# Altium schematic sheet SCH40.001R5.SchDoc
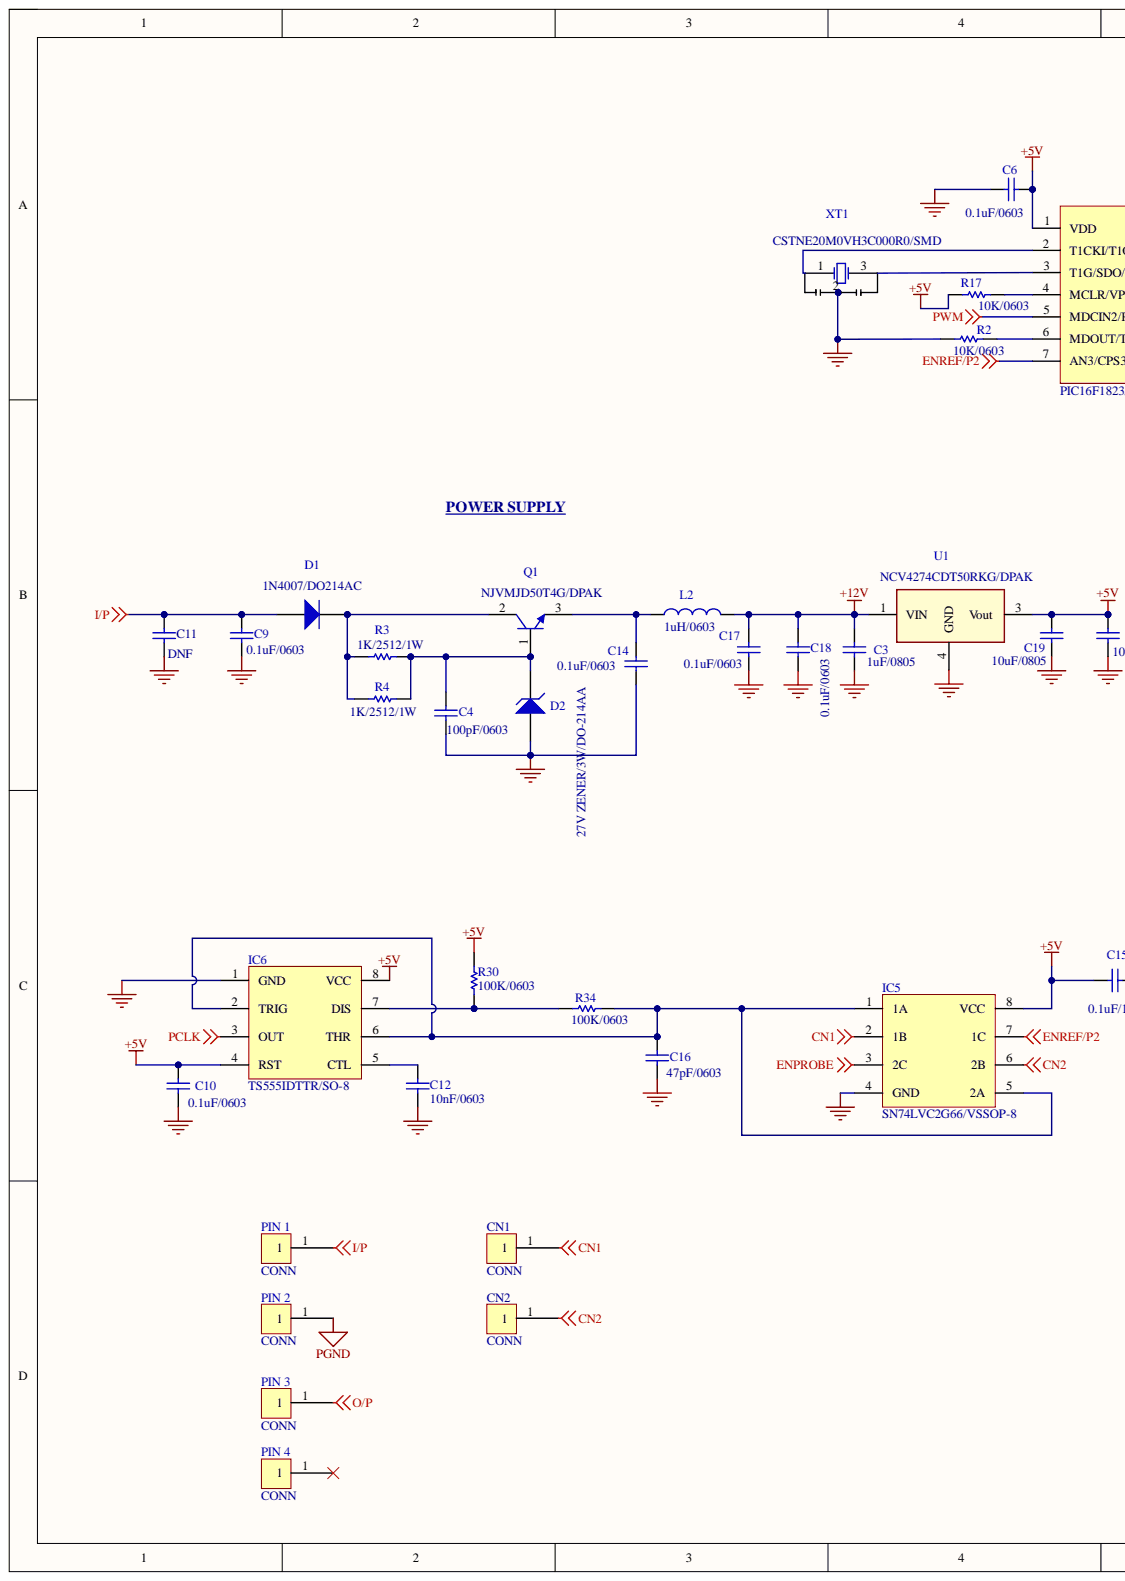
[diagram p0: SCH40.001R5.SchDoc, left]
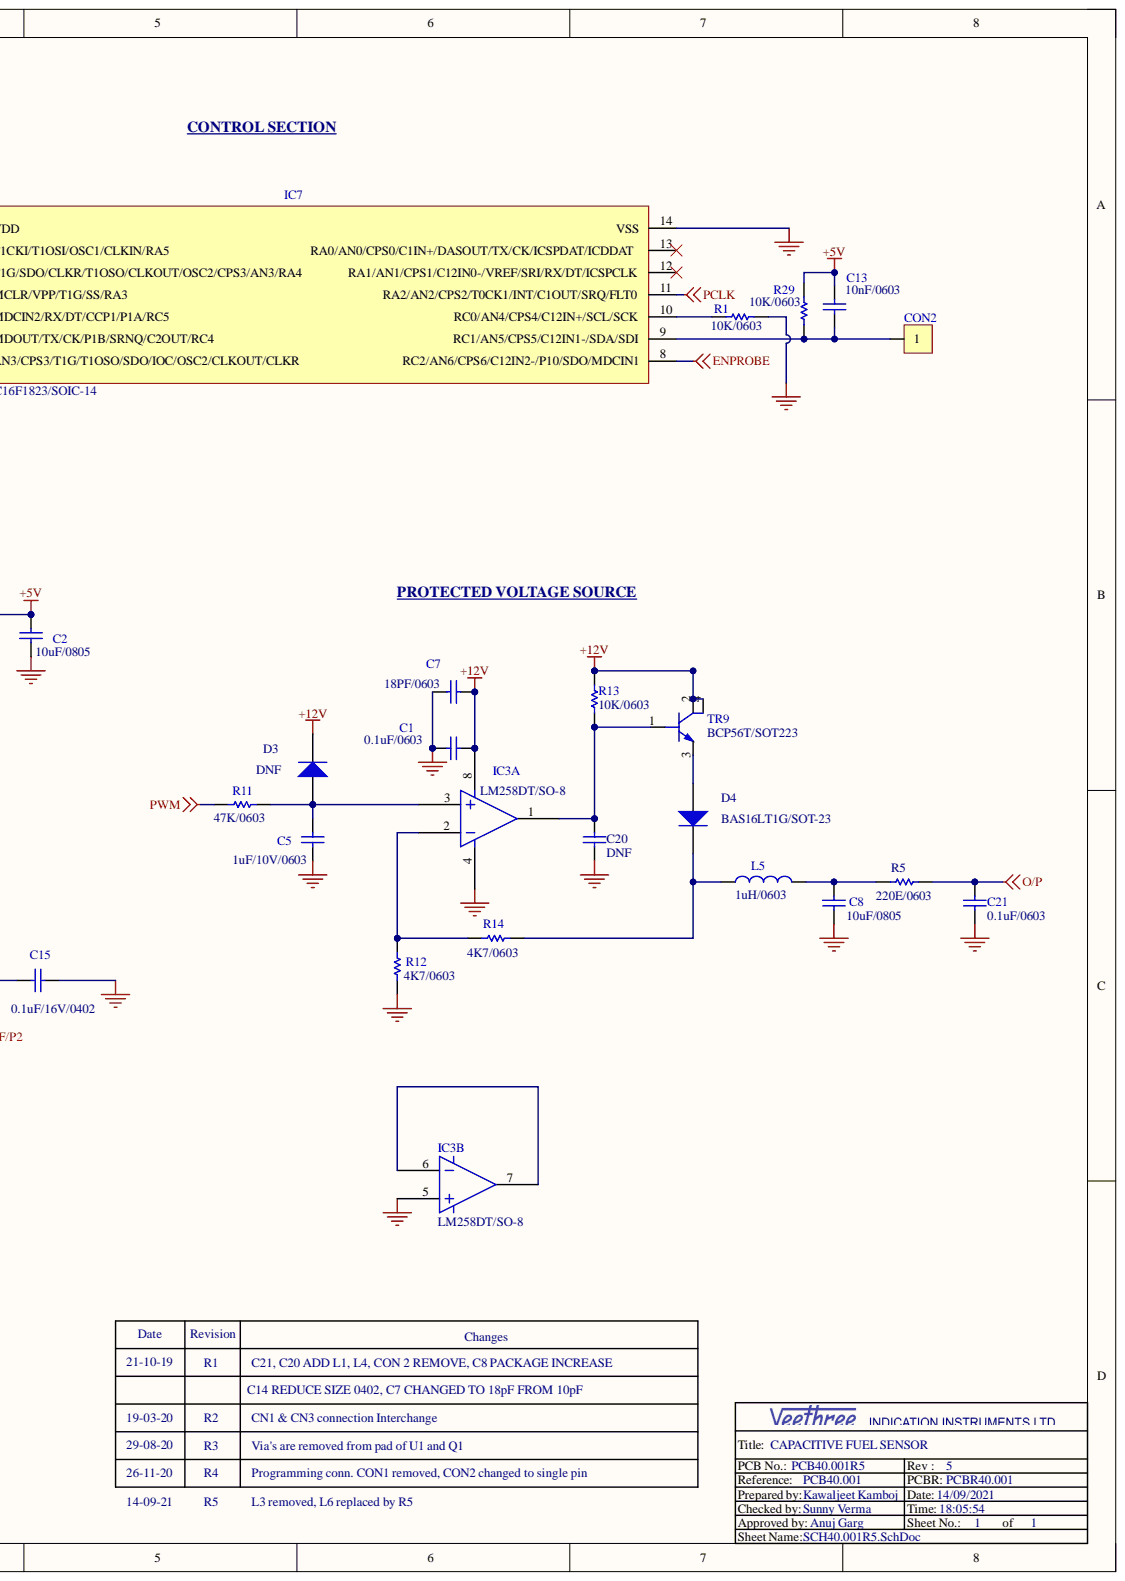
[diagram p1: SCH40.001R5.SchDoc, right]

## Parts
1  (no value)
C1  0.1uF/0603  [0603]
C2  10uF/0805  [0805]
C3  1uF/0805  [0805]
C4  100pF/0603  [0603]
C5  1uF/10V/0603  [0603]
C6  0.1uF/0603  [0603]
C7  18PF/0603  [0603]
C8  10uF/0805  [0805]
C9  0.1uF/0603  [0603]
C10  0.1uF/0603  [0603]
C11  DNF  [0603]
C12  10nF/0603  [0603]
C13  10nF/0603  [0603]
C14  0.1uF/0603  [0603]
C15  0.1uF/16V/0402  [0402]
C16  47pF/0603  [0603]
C17  0.1uF/0603  [0603]
C18  0.1uF/0603  [0603]
C19  10uF/0805  [0805]
C20  DNF  [0603]
C21  0.1uF/0603  [0603]
CN1  CONN  [PAD]
CN2  CONN  [PAD]
CON2  Header 1  [HD1]  (value not drawn)
D1  1N4007/DO214AC  [DO-214AC]
D2  27V ZENER/3W/DO-214AA  [DO-214AA(SMB)]
D3  DNF  [SOT23]
D4  BAS16LT1G/SOT-23  [SOT23]
IC3  LM258DT/SO-8  [SO-8]  (parts A,B)
IC5  SN74LVC2G66/VSSOP-8  [VSSOP-8]
IC6  TS555IDTTR/SO-8  [SO-8]
IC7  PIC16F1823/SOIC-14  [SO-14]
L2  1uH/0603  [0603]
L5  1uH/0603  [0603]
PIN_1  CONN  [PAD - rectangle]
PIN_2  CONN  [PAD - rectangle]
PIN_3  CONN  [PAD - rectangle]
PIN_4  CONN  [PAD - rectangle]
Q1  NJVMJD50T4G/DPAK  [DPAK]
R1  10K/0603  [0603]
R2  10K/0603  [0603]
R3  1K/2512/1W  [2512]
R4  1K/2512/1W  [2512]
R5  220E/0603  [0603]
R11  47K/0603  [0603]
R12  4K7/0603  [0603]
R13  10K/0603  [0603]
R14  4K7/0603  [0603]
R17  10K/0603  [0603]
R29  10K/0603  [0603]
R30  100K/0603  [0603]
R34  100K/0603  [0603]
TR9  BCP56T/SOT223  [SOT-223]
U1  NCV4274CDT50RKG/DPAK  [DPAK]
XT1  CSTNE20M0VH3C000R0/SMD  [RESONATOR_CSCTE16MOV53]

## Nets
+5V: C2.1 C6.2 C10.2 C13.1 C15.1 C19.1 IC5.8(VCC) IC6.4(RST) IC6.8(VCC) IC7.1(VDD) R17.2 R29.1 R30.2 U1.3
+12V: C1.1 C3.1 C7.1 C17.1 C18.1 D3.3 IC3.8 L2.2 R13.2 TR9.2 TR9.4 U1.1
CN1: CN1.1 IC5.2(1B)
CN2: CN2.1 IC5.6(2B)
ENPROBE: IC5.3(2C) IC7.8(RC2/AN6/CPS6/C12IN2-/P10/SDO/MDCIN1)
ENREF/P2: IC5.7(1C) IC7.7(AN3/CPS3/T1G/T1OSO/SDO/IOC/OSC2/CLKOUT/CLKR)
GND: C1.2 C2.2 C3.2 C4.2 C5.2 C6.1 C7.2 C8.2 C9.2 C10.1 C11.2 C12.1 C14.2 C15.2 C16.1 C17.2 C18.2 C19.2 C20.2 C21.2 D2.1 IC3.4 IC3.5 IC5.4(GND) IC6.1(GND) IC7.14(VSS) R1.1 R2.1 R12.1 U1.4 XT1.2
I/P: C9.1 C11.1 D1.1 PIN_1.1
O/P: C21.1 PIN_3.1 R5.1
PCLK: IC6.3(OUT) IC7.11(RA2/AN2/CPS2/T0CK1/INT/C1OUT/SRQ/FLT0)
PGND: PIN_2.1
PWM: IC7.5(MDCIN2/RX/DT/CCP1/P1A/RC5) R11.2
NetC4_1: C4.1 D2.2 Q1.1 R3.2 R4.2
NetC5_1: C5.1 D3.1 IC3.3 R11.1
NetC8_1: C8.1 L5.2 R5.2
NetC12_2: C12.2 IC6.5(CTL)
NetC13_2: C13.2 CON2.1 IC7.9(RC1/AN5/CPS5/C12IN1-/SDA/SDI) R29.2
NetC14_1: C14.1 L2.1 Q1.3
NetC16_2: C16.2 IC5.1(1A) IC5.5(2A) IC6.2(TRIG) IC6.6(THR) R34.2
NetC20_1: C20.1 IC3.1 R13.1 TR9.1
NetD1_2: D1.2 Q1.2 R3.1 R4.1
NetD4_1: D4.1 TR9.3
NetD4_3: D4.3 L5.1 R14.1
NetIC3_2: IC3.2 R12.2 R14.2
NetIC3_6: IC3.6 IC3.7
NetIC6_7: IC6.7(DIS) R30.1 R34.1
NetIC7_2: IC7.2(T1CKI/T1OSI/OSC1/CLKIN/RA5) XT1.1
NetIC7_3: IC7.3(T1G/SDO/CLKR/T1OSO/CLKOUT/OSC2/CPS3/AN3/RA4) XT1.3
NetIC7_4: IC7.4(MCLR/VPP/T1G/SS/RA3) R17.1
NetIC7_6: IC7.6(MDOUT/TX/CK/P1B/SRNQ/C2OUT/RC4) R2.2
NetIC7_10: IC7.10(RC0/AN4/CPS4/C12IN+/SCL/SCK) R1.2

Not connected: IC7 12(RA1/AN1/CPS1/C12IN0-/VREF/SRI/RX/DT/ICSPCLK) 13(RA0/AN0/CPS0/C1IN+/DASOUT/TX/CK/ICSPDAT/ICDDAT); PIN_4 1

## Library data of the parts
1 = revision: Component Kind: Standard; Library Name: VEETHREE LIB.SchLib
C1-C4 C6-C14 C16-C21 = Cap: Capacitor; Component Kind: Standard; LatestRevisionDate: 17-Jul-2002; LatestRevisionNote: Re-released for DXP Platform.; Library Name: Miscellaneous Devices.IntLib; PackageDescription: Radial Cap, Thru-Hole; 2 Leads; 0.3 in Pin Spacing; PackageReference: RAD-0.3; Published: 8-Jun-2000; Signal Integrity: Cap; Simulation: CAP; Publisher: Altium Limited
  C1 C6 C9 C10 C14 C17 C18 C21: Value: 0.1uF/0603
  C2 C8 C19: Value: 10uF/0805
  C3: Value: 1uF/0805
  C4: Value: 100pF/0603
  C7: Value: 18PF/0603
  C11 C20: Value: DNF
  C12 C13: Value: 10nF/0603
  C16: Value: 47pF/0603
C5 = Cap: Capacitor; Value: 1uF/0603; Component Kind: Standard; LatestRevisionDate: 17-Jul-2002; LatestRevisionNote: Re-released for DXP Platform.; Library Name: Miscellaneous Devices.IntLib; PackageDescription: Radial Cap, Thru-Hole; 2 Leads; 0.3 in Pin Spacing; PackageReference: RAD-0.3; Published: 8-Jun-2000; Signal Integrity: Cap; Simulation: CAP; Publisher: Altium Limited
C15 = Cap: Capacitor; Value: 0.1uF/0402; Component Kind: Standard; LatestRevisionDate: 17-Jul-2002; LatestRevisionNote: Re-released for DXP Platform.; Library Name: Miscellaneous Devices.IntLib; PackageDescription: Radial Cap, Thru-Hole; 2 Leads; 0.3 in Pin Spacing; PackageReference: RAD-0.3; Published: 8-Jun-2000; Signal Integrity: Cap; Simulation: CAP; Publisher: Altium Limited
CN1 CN2 PIN_1-PIN_4 = CONN_1_PIN: Component Kind: Standard; Library Name: Veethree.SchLib
D1 D3 D4 = Diode 1N4007: 1 Amp General Purpose Rectifier; Code_JEDEC: DO-204-AL; Component Kind: Standard; ComponentLink1Description: Manufacturer Link; ComponentLink1URL: http://www.fairchildsemi.com/; ComponentLink2Description: Datasheet; ComponentLink2URL: http://www.fairchildsemi.com/ds/1N%2F1N4001.pdf; DatasheetVersion: 1998; LatestRevisionDate: 17-Jul-2002; LatestRevisionNote: Re-released for DXP Platform.; Library Name: Miscellaneous Devices.IntLib; PackageDescription: Diode, Axial; Body 5.2 x 2.7 mm (LxDia. max), Lead Dia. 0.86 mm (max); PackageReference: DO-41; PackageVersion: Aug-1999; Published: 8-Jun-2000; Signal Integrity: 1N4007; Simulation: 1N4007; Publisher: Altium Limited
D2 = D Zener: Zener Diode; Component Kind: Standard; LatestRevisionDate: 17-Jul-2002; LatestRevisionNote: Re-released for DXP Platform.; Library Name: Miscellaneous Devices.IntLib; PackageDescription: Diode, Thru-Hole; 2 Leads; 0.7 in Pin Spacing; PackageReference: DIODE-0.7; Published: 8-Jun-2000; Signal Integrity: D Zener; Simulation: ZENER; Publisher: Altium Limited
IC3 = 1458: Component Kind: Standard; Library Name: d_opamps.lib
IC7 = 16F1823: Component Kind: Standard; Library Name: Veethree.SchLib
L2 L5 = INDUCTOR1: Component Kind: Standard; Library Name: d_device.lib
Q1 = NPN: NPN Bipolar Transistor; Code_JEDEC: TO-226-AA; Component Kind: Standard; LatestRevisionDate: 17-Jul-2002; LatestRevisionNote: Re-released for DXP Platform.; Library Name: Miscellaneous Devices.IntLib; PackageDescription: TO, Flat Index; 3 In-Line, Axial Leads; Body Dia. 4.8mm; Leads 0.55 x 0.51 mm (max); PackageReference: TO-226-AA; Published: 8-Jun-2000; Signal Integrity: NPN; Simulation: NPN; Publisher: Altium Limited
R1-R4 R11-R14 R17 R29 R30 R34 = Res Semi: Semiconductor Resistor; Component Kind: Standard; LatestRevisionDate: 17-Jul-2002; LatestRevisionNote: Re-released for DXP Platform.; Library Name: Miscellaneous Devices.IntLib; PackageDescription: Axial Device, Thru-Hole; 2 Leads; 0.5 in Pin Spacing; PackageReference: AXIAL-0.5; Published: 8-Jun-2000; Signal Integrity: Res Semi; Simulation: RES; Publisher: Altium Limited
  R1 R2 R13 R17 R29: Value: 10K/0603
  R3 R4: Value: 1K/2512/1W
  R11: Value: 47K/0603
  R12 R14: Value: 4K7/0603
  R30 R34: Value: 100K/0603
R5 = Res Semi: Semiconductor Resistor; Value: 4K7/0603; Component Kind: Standard; LatestRevisionDate: 17-Jul-2002; LatestRevisionNote: Re-released for DXP Platform.; Library Name: Miscellaneous Devices.IntLib; PackageDescription: Axial Device, Thru-Hole; 2 Leads; 0.5 in Pin Spacing; PackageReference: AXIAL-0.5; Published: 8-Jun-2000; Signal Integrity: Res Semi; Simulation: RES; Publisher: Altium Limited
TR9 = BCP56: Component Kind: Standard; Library Name: VEETHREE LIB.SchLib
U1 = NCV4274ADT50RKG: REGULATOR; Component Kind: Standard; Library Name: REGULATOR.SchLib
XT1 = XTAL: Crystal Oscillator; Component Kind: Standard; LatestRevisionDate: 17-Jul-2002; LatestRevisionNote: Re-released for DXP Platform.; PackageDescription: Crystal, Thru-Hole; 2 Leads; Body 3.1 x 8.2 mm (Dia.xH); PackageReference: R38; Published: 8-Jun-2000; Simulation: XTAL; Library Name: Capacitive fuel sensor 2.SCHLIB; Publisher: Altium Limited
CON2 = Header 1: Component Kind: Standard; Library Name: Kawal.SCHLIB
IC5 = SN74LVC2G66/VSSOP-8: Component Kind: Standard; Library Name: Kawal.SCHLIB
IC6 = TS555IDTTR/SO-8: Component Kind: Standard; Library Name: Kawal.SCHLIB
